# Altium schematic sheet DistanceSensor.SchDoc
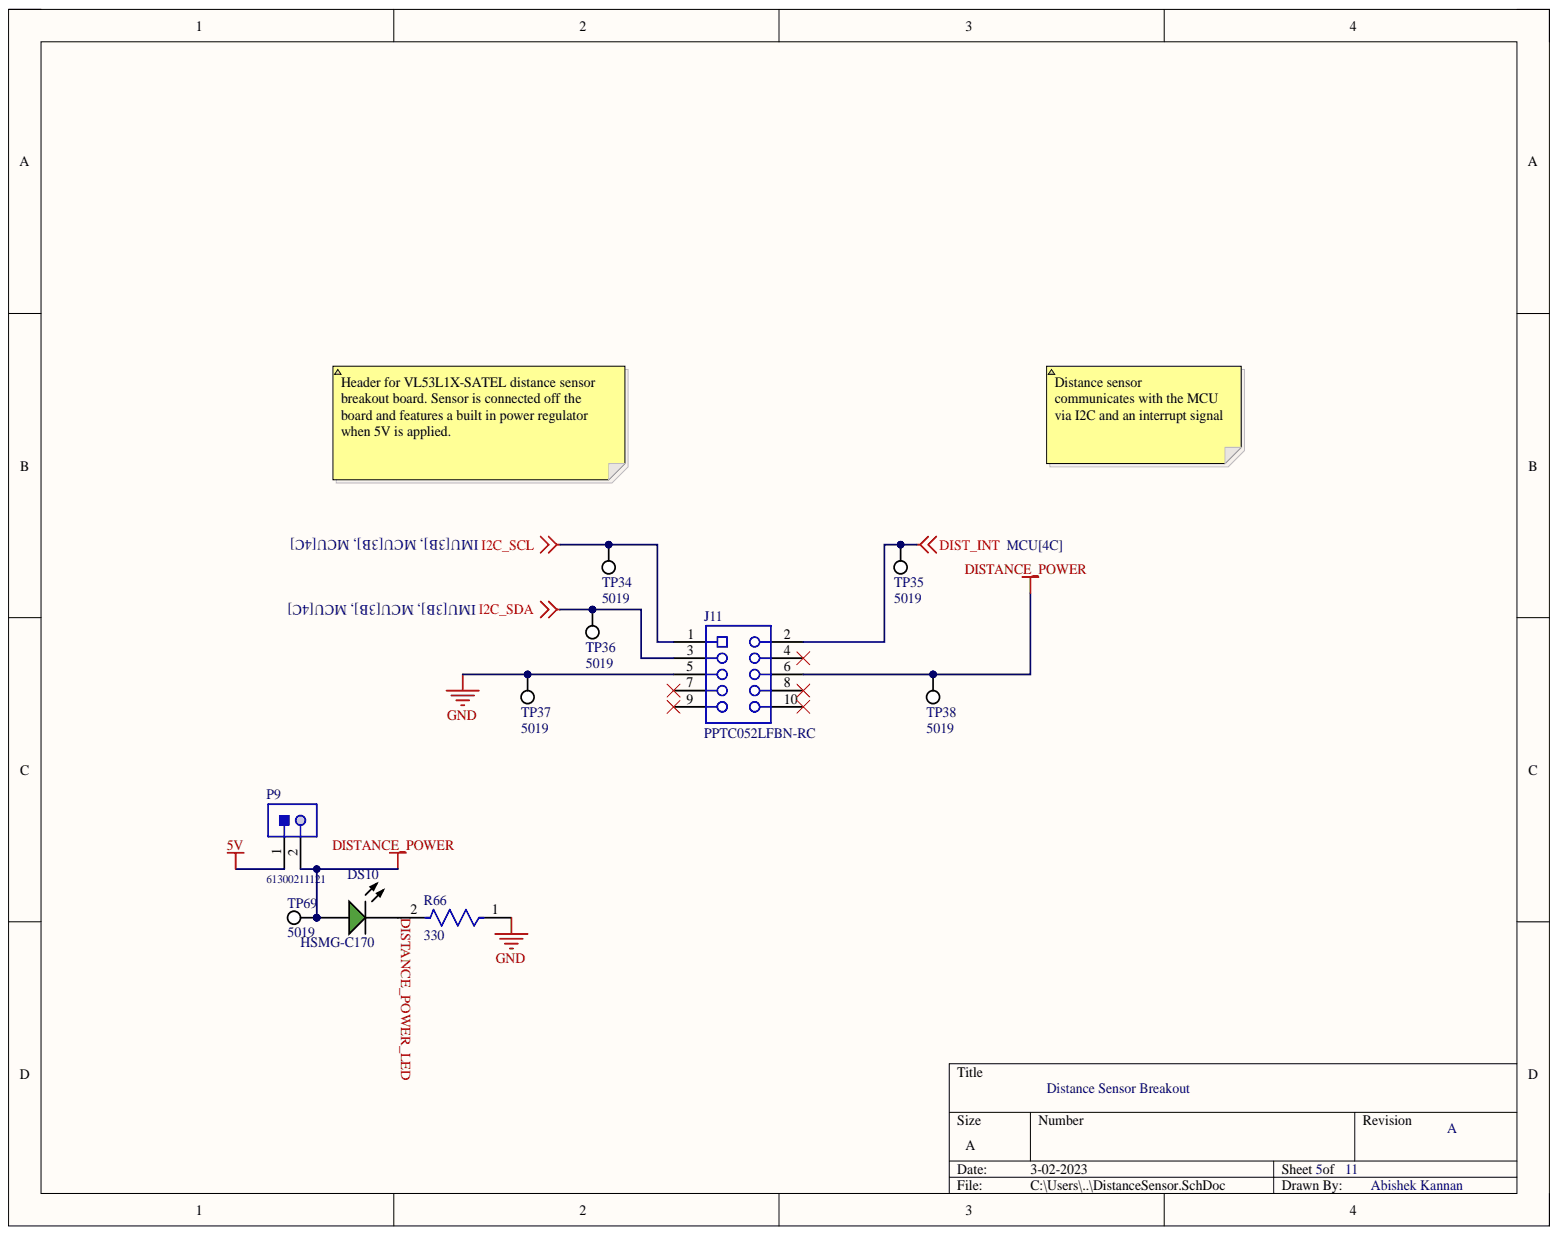
[diagram p0: DistanceSensor.SchDoc]

## Parts
DS10  HSMG-C170  [AVAG-HSMX-C170_V]
J11  PPTC052LFBN-RC  [SLIN-PPTC052LFBN-RC_V]
P9  61300211121  [61300211121]
R66  330  [FP-CRCW0805-e3-MFG]
TP34  5019  [KSTN-5019_V]
TP35  5019  [KSTN-5019_V]
TP36  5019  [KSTN-5019_V]
TP37  5019  [KSTN-5019_V]
TP38  5019  [KSTN-5019_V]
TP69  5019  [KSTN-5019_V]

## Nets
5V: P9.1
DIST_INT: J11.2 TP35.1
DISTANCE_POWER: DS10.1 J11.6 P9.2 TP38.1 TP69.1
GND: J11.5 R66.1 TP37.1
I2C_SCL: J11.1 TP34.1
I2C_SDA: J11.3 TP36.1
DISTANCE_POWER_LED: DS10.2 R66.2

Not connected: J11 4 7 8 9 10

## Library data of the parts
DS10 = CMP-2000-05062-1: Chip LED, Green, 2.2 V, -40 to 85 degC, 2-Pin SMD, Pb-Free, Tape and Reel; Manufacturer: Avago; Bulb Size: 1.25mmx1.4mm; Dominant Wavelength: 572nm; External Depth: 0.8mm; External Length / Height: 2mm; External Width: 1.25mm; Forward Current If: 20mA; Forward Voltage: 2.2V; Forward Voltage VF Max: 2.6V; LED Type: Standard; Lens Shape: Rectangular; Light Area Height: 1mm; Luminous Intensity: 15mcd; Luminous Intensity Min: 4mcd; No. of Pins: 2; Opto Mounting Orientation: Top; Peak Wavelength: 570nm; PIV Max: 5V; SVHC: NoSVHC(16-Jun-2014); Viewing Angle: 170deg; Component Kind: Standard; Datasheet URL: https://datasheet.ciiva.com/29280/180495-da-01-en-chip-led-hsmg-c170-gelb-gruen-29280971.pdf; Datasheet Version: Oct-08; Dominant Wavelength Typ: 572nm; Forward Current If Max: 20mA; LED Colour: Green; LED Mounting: SMD; Library Name: Altium Content Vault; Luminous Intensity Typ: 15mcd; Manufacturer URL: http://www.avagotech.com/; Mounting Type: SMD; MSL: MSL2A-4weeks; Operating Temperature Max: 85degC; Operating Temperature Min: -30degC; Operating Temperature Range: -30degCto+85degC; Opto Case Style: SMD; Package Description: 2-Pin Surface Mount Device, Body 2 x 1.25 mm, Height 0.8 mm; Package Reference: HSMX-C170; Packaging: CutTape; Peak Forward Current: 20mA; Storage Temperature Max: 85degC; Storage Temperature Min: -40degC; Voltage Vf Typ: 2.2V; Wavelength Typ: 570nm
J11 = CMP-2000-06912-1: Receptacle, Pitch 2.54 mm, 2 x 5 Position, Height 8.5 mm, Tail Length 3.2 mm, -40 to 105 degC, RoHS; Manufacturer: Sullins; Catalog Drawings: PPSeriesDualFemale    PPSeriesFootprint; Connector Type: Header; Dynamic Catalog: PPSeries; Mating Products: S2351E-05-ND  -CONNHEADER10POS.100DUALSMD  S2331E-05-ND  -CONNHEADER10POS.100DUALSMD  S2321E-05-ND  -CONNHEADER.100DUALR/A10POS  S2311E-05-ND  -CONNHEADER.100DUALR/A10POS  S2151E-05-ND  -CONNHEADER.100DUALR/A10POS  S2141E-05-ND  -CONNHEADER.100DUALR/A10POS  S2131E-05-ND  -CONNHEADER.100DUALR/A10POS  S2121E-05-ND  -CONNHEADER.100DUALR/A10POS  S2111E-05-ND  -CONNHEADER.100DUALR/A10POS  S2322E-05-ND  -CONNHEADER.100DUALR/A10POS  More...; Number of Positions: 10; Number of Positions Loaded: All; Other Names: S6105; Pitch: 0.100"(2.54mm); Category: Connectors,Interconnects; Color: Black; Component Kind: Standard; Contact Finish: Tin; Contact Type: FemaleSocket; Datasheet URL: http://www.sullinscorp.com/drawings/101_P(N)PxCxxxLFBN-RC,_10492.pdf; Datasheet Version: Rev. H, 02/2014; Family: RectangularConnectors-Headers,Receptacles,FemaleSockets; Library Name: Altium Content Vault; Manufacturer URL: http://www.sullinscorp.com/; Mounting Technology: ThroughHole; Mounting Type: ThroughHole; Number of Rows: 2; Package Description: Female Header, Pitch 2.54 mm, 2 x 5 Position, Height 8.5 mm, Tail Length 3.2 mm; Package Reference: PPTC052LFBN-RC; Packaging: Bulk; Row Spacing: 0.100"(2.54mm); Standard Package: 1,000; Termination: Solder
P9 = CMP-1712-00002-4: THT Vertical Pin Header WR-PHD, Pitch 2.54 mm, Single Row, 2 pins; Manufacturer: Wurth Elektronik; Connector Type: Male Pin; Contact Resistance: 20mOhm; Current Rating: 3A; Gender: Male; Number of Contacts: 2; Pitch: 2.54mm; Voltage Rating: 250V; Withstanding Voltage: 500VAC; Working Voltage: 250VAC; Category: Headers and Wire Housings; Color: Black; Component Kind: Standard; ComponentLink1Description: https://datasheet.ciiva.com/13619/6130xx11121-48870-13619345.pdf?src-supplier=Mouser; ComponentLink1URL: https://datasheet.ciiva.com/13619/6130xx11121-48870-13619345.pdf?src-supplier=Mouser; Contact Gender: Male; Contact Material: Copper Alloy,Copper; Contact Mating Length: 0.236inch; Contact Plating: Gold; Contact Type: Male Pin; Datasheet URL: https://katalog.we-online.de/em/datasheet/6130xx11121.pdf; Housing Material: PBT; Lead Free: Lead Free; Library Name: Altium Content Vault; Manufacturer Part Number: 61300211121; Manufacturer URL: http://www.we-online.de; Max Operating Temperature: 125°C; Min Operating Temperature: -40°C; Mounting Technology: THT; Number of Positions: 2; Number of Rows: 1; Operating Temperature: -40to105degC; Orientation: Vertical; Package Reference: 61300211121; Packaging: Bag; Part Number: 61300211121; Pin Number: 2; Pricing 1: 1=0.13000, 10=0.11300, 25=0.09400, 50=0.07900, 100=0.07100, 1000=0.06500 (USD); Rated Current: 3A; RoHS: Yes; RoHS Compliant: Yes; Row Number: Single; Series: WR-PHD; Stock 1: 204500; Supplier 1: Digi-Key; Supplier Part Number 1: 732-5315-ND; Termination: Through Hole; Publisher: WurthElektronik
R66 = CMP-2001-03823-2: RES Thick Film, 330Ω, 1%, 0.125W, 100ppm/°C, 0805; Manufacturer: Vishay; Manufacturer Part Number: CRCW0805330RFKEA; Max Power Dissipation: 125mW; Resistance: 330R; Voltage Rating: 150V; Case Code (Imperial): 0805; Case Code (Metric): 2012; Case/Package: 0805; Category: Chip SMD Resistors; Component Kind: Standard; ComponentLink1Description: https://datasheet.ciiva.com/8944/dcrcwe3-8944773.pdf?src-supplier=Avnet; ComponentLink1URL: https://datasheet.ciiva.com/8944/dcrcwe3-8944773.pdf?src-supplier=Avnet; ComponentLink2Description: https://datasheet.ciiva.com/8944/dcrcwe3-8944776.pdf?src-supplier=Avnet; ComponentLink2URL: https://datasheet.ciiva.com/8944/dcrcwe3-8944776.pdf?src-supplier=Avnet; ComponentLink3Description: https://datasheet.ciiva.com/2495/dcrcwe3-2495803.pdf?src-supplier=Digi-Key; ComponentLink3URL: https://datasheet.ciiva.com/2495/dcrcwe3-2495803.pdf?src-supplier=Digi-Key; ComponentLink4Description: https://datasheet.ciiva.com/2495/dcrcwe3-2495804.pdf?src-supplier=Digi-Key; ComponentLink4URL: https://datasheet.ciiva.com/2495/dcrcwe3-2495804.pdf?src-supplier=Digi-Key; ComponentLink5Description: https://datasheet.ciiva.com/2495/dcrcwe3-2495805.pdf?src-supplier=Digi-Key; ComponentLink5URL: https://datasheet.ciiva.com/2495/dcrcwe3-2495805.pdf?src-supplier=Digi-Key; ComponentLink6Description: https://datasheet.ciiva.com/5545/dcrcwe3-109170-5545357.pdf?src-supplier=Mouser; ComponentLink6URL: https://datasheet.ciiva.com/5545/dcrcwe3-109170-5545357.pdf?src-supplier=Mouser; ComponentLink7Description: https://datasheet.ciiva.com/16769/70201119-16769852.pdf?src-supplier=Allied+Electronics; ComponentLink7URL: https://datasheet.ciiva.com/16769/70201119-16769852.pdf?src-supplier=Allied+Electronics; ComponentLink8Description: https://datasheet.ciiva.com/7777/1697337-7777390.pdf?src-supplier=Element14; ComponentLink8URL: https://datasheet.ciiva.com/7777/1697337-7777390.pdf?src-supplier=Element14; ComponentLink9Description: https://datasheet.ciiva.com/7777/1720486-7777573.pdf?src-supplier=Element14; ComponentLink9URL: https://datasheet.ciiva.com/7777/1720486-7777573.pdf?src-supplier=Element14; Composition: Thick Film; Construction: Rectangular; Contact Plating: Tin; Depth: 1.25mm; Features: Automotive AEC-Q200; Height: 0.02inch; Lead Free: Lead Free; Length: 2mm; Library Name: Altium Content Vault; Max Operating Temperature: 155°C; Military Standard: Not; Min Operating Temperature: -55°C; Mount: Surface Mount; Number of Terminations: 2; Package Quantity: 10; Packaging: Tape and Reel; Power Rating: 125mW; Pricing 1: 1=0.10000, 10=0.06700, 100=0.02710, 1000=0.01219, 2500=0.01058 (USD); Ratings: AEC-Q200; Resistance Tolerance: 1%; Resistor Type: Thick Film; RoHS: Yes; RoHS Compliant: Yes; Series: CRCW; Size Code: 0805; Stock 1: 1889; Supplier 1: Digi-Key; Supplier Part Number 1: 541-330CCT-ND; Temperature Coefficient: 100ppm/°C; Termination: SMD/SMT; Tolerance: 1%; Voltage Rating (DC): 150V; Weight: 0.000194oz; Width: 0.049inch
TP34-TP38 TP69 = CMP-1672-00008-1: Test Point, 1 Position SMD, RoHS, Tape and Reel; Manufacturer: Keystone Electronics; Body Material: Bronze; Plating: Silver; Category: Connectors; Component Kind: Standard; ComponentLink1Description: Manufacturer URL; ComponentLink1URL: http://www.keyelco.com/; ComponentLink2Description: Datasheet; ComponentLink2URL: http://www.keyelco.com/product-pdf.cfm?p=1357; ComponentLink3Description: https://datasheet.ciiva.com/16885/70182998-16885945.pdf?src-supplier=Allied+Electronics; ComponentLink3URL: https://datasheet.ciiva.com/16885/70182998-16885945.pdf?src-supplier=Allied+Electronics; ComponentLink4Description: https://datasheet.ciiva.com/14848/m60-2p61-14848437.pdf?src-supplier=Digi-Key; ComponentLink4URL: https://datasheet.ciiva.com/14848/m60-2p61-14848437.pdf?src-supplier=Digi-Key; ComponentLink5Description: https://datasheet.ciiva.com/14848/m60-2p61-14848438.pdf?src-supplier=Digi-Key; ComponentLink5URL: https://datasheet.ciiva.com/14848/m60-2p61-14848438.pdf?src-supplier=Digi-Key; ComponentLink6Description: https://datasheet.ciiva.com/14848/m60-2p61-14848439.pdf?src-supplier=Digi-Key; ComponentLink6URL: https://datasheet.ciiva.com/14848/m60-2p61-14848439.pdf?src-supplier=Digi-Key; ComponentLink7Description: https://datasheet.ciiva.com/16318/m60-2p61-16318395.pdf?src-supplier=Future+Electronics; ComponentLink7URL: https://datasheet.ciiva.com/16318/m60-2p61-16318395.pdf?src-supplier=Future+Electronics; Contact Material: Bronze; Contact Plating: Silver; DatasheetVersion: 03/2014; Height: 0.09inch; Lead Free: Lead Free; Library Name: Altium Content Vault; Manufacturer Part Number: 5019; Material: Bronze; Mount: Surface Mount; Mounting Technology: Surface Mount; Number of Pins: 1; Package Quantity: 1000; PackageDescription: 1-Pin Surface Mount Device, Body 3.81 x 2.03 mm; PackageReference: 5019; Packaging: Tape and Reel; Pins: 1; Pricing 1: 1=0.34000, 25=0.27360, 100=0.22340, 250=0.20064, 500=0.19152 (USD); Reach SVHC Compliant: Yes; RoHS: Yes; RoHS Compliant: Yes; RoHSCompliant: True; Series: 5019; Stock 1: 1009631; Supplier 1: Digi-Key; Supplier Part Number 1: 36-5019CT-ND; Termination: SMD/SMT
